# Altium schematic sheet 6.ENERGY.SchDoc
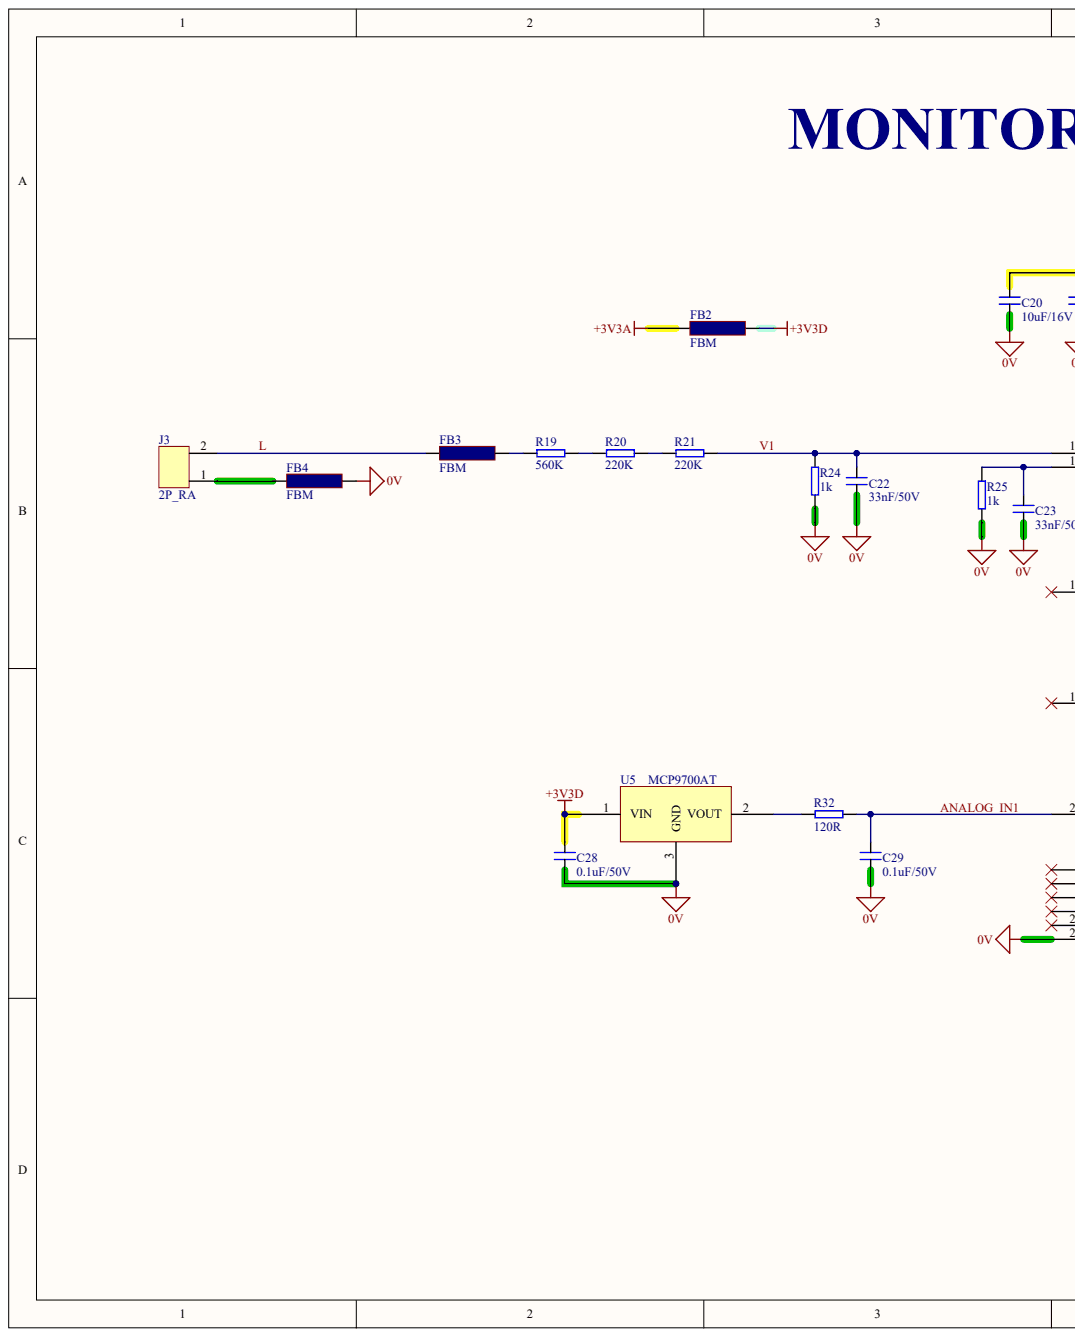
[diagram p0: 6.ENERGY.SchDoc, left]
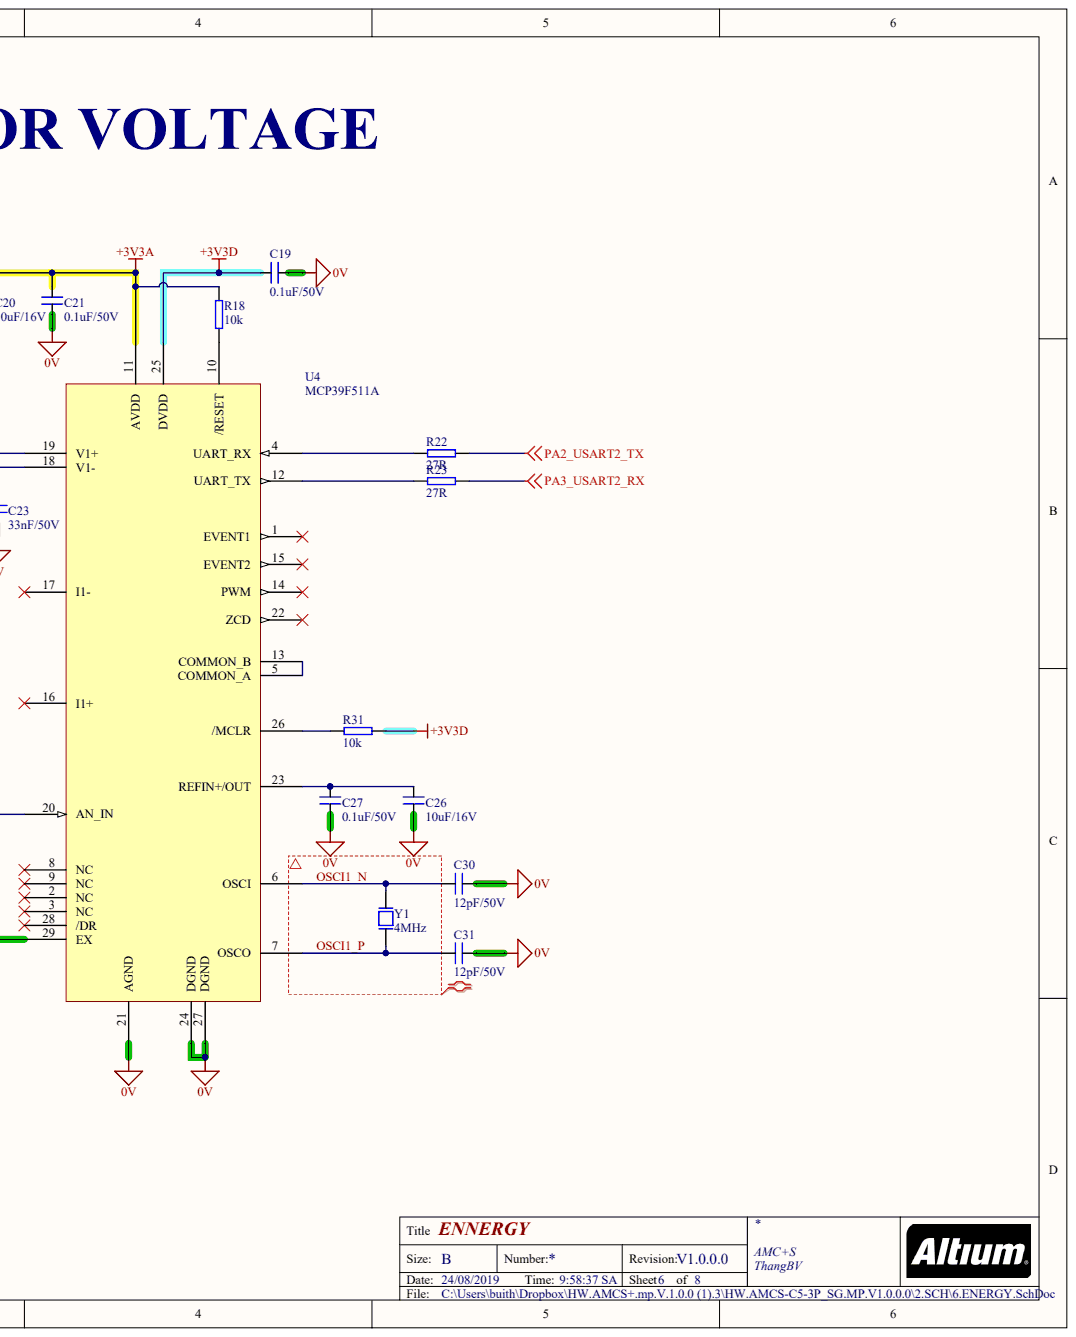
[diagram p1: 6.ENERGY.SchDoc, right]

## Parts
C19  0.1uF/50V  [0805]
C20  10uF/16V  [0805]
C21  0.1uF/50V  [0805]
C22  33nF/50V  [0805]
C23  33nF/50V  [0805]
C26  10uF/16V  [0805]
C27  0.1uF/50V  [0805]
C28  0.1uF/50V  [0805]
C29  0.1uF/50V  [0805]
C30  12pF/50V  [0805]
C31  12pF/50V  [0805]
FB2  FBM  [0805]
FB3  FBM  [0805]
FB4  FBM  [0805]
J3  2P_RA  [CONN_2x1_5.08_RA]
R18  10k  [0805]
R19  560K  [0805]
R20  220K  [0805]
R21  220K  [0805]
R22  27R  [0805]
R23  27R  [0805]
R24  1k  [0805]
R25  1k  [0805]
R31  10k  [0805]
R32  120R  [0805]
U4  MCP39F511A  [QFN-28-EX]
U5  MCP9700AT  [SOT-23]
Y1  4MHz  [49-SMD]

## Nets
0V: C19.2 C20.2 C21.2 C22.1 C23.1 C26.1 C27.2 C28.2 C29.2 C30.1 C31.1 FB4.2 R24.2 R25.2 U4.21(AGND) U4.24(DGND) U4.27(DGND) U4.29(EX) U5.3
+3V3A: C20.1 C21.1 FB2.1 R18.1 U4.11(AVDD)
+3V3D: C19.1 C28.1 FB2.2 R31.1 U4.25(DVDD) U5.1
PA2_USART2_TX: R22.2
PA3_USART2_RX: R23.2
ANALOG_IN1: C29.1 R32.1 U4.20(AN_IN)
L: FB3.1 J3.2
OSCI1_N: C30.2 U4.6(OSCI) Y1.2
OSCI1_P: C31.2 U4.7(OSCO) Y1.1
V1: C22.2 R21.2 R24.1 U4.19(V1+)
NetC23_2: C23.2 R25.1 U4.18(V1-)
NetC26_2: C26.2 C27.1 U4.23(REFIN+/OUT)
NetFB3_2: FB3.2 R19.1
NetFB4_1: FB4.1 J3.1
NetR18_2: R18.2 U4.10(/RESET)
NetR19_2: R19.2 R20.1
NetR20_2: R20.2 R21.1
NetR22_1: R22.1 U4.4(UART_RX)
NetR23_1: R23.1 U4.12(UART_TX)
NetR31_2: R31.2 U4.26(/MCLR)
NetR32_2: R32.2 U5.2
NetU4_5: U4.5(COMMON_A) U4.13(COMMON_B)
N00130:

Not connected: U4 1(EVENT1) 2(NC) 3(NC) 8(NC) 9(NC) 14(PWM) 15(EVENT2) 16(I1+) 17(I1-) 22(ZCD) 28(/DR)

## Library data of the parts
C19 C21 C27-C29 = Cap_0.1uF_0805: CAP CER 0.1UF 50V Y5V 0805; MFR: Yageo; Part Number: CC0805ZRY5V9BB104; AMCS CODE: VT-CAP-0004; ASS: SMT; Component Kind: Standard; Package: 0805; TYPE: CAP; Library Name: Schlib_Diepvn_V01.SchLib
C20 C26 = Cap_10uF_0805: CAP CER 10UF 16V 20% X5R 0805; MFR: Murata; Part Number: GRM21BR61E106KA73L; AMCS CODE: VT-CAP-0005; ASS: SMT; Component Kind: Standard; Package: 0805; TYPE: CAP; Library Name: Schlib_Diepvn_V01.SchLib
C22 C23 = Cap_33nF_0805: CAP CER 0.033UF 50V Y5V 0805; MFR: Panasonic Electronic Components; Part Number: ECJ-2VF1H333Z; Vender Part: PCC1861DKR-ND; ASS: SMT; LINK 1: *https://kme.com.vn/products/tu-gom-0805-33nf-cog-50v; LINK 2: *https://thegioiic.com/products/tu-33nf-50v-0805; Vender: Digikey; Component Kind: Standard; Package: 0805 (2012 Metric); TYPE: CAP; Library Name: Schlib_Diepvn_V01.SchLib
C30 C31 = Cap_12pF_0805: CAP CER 12PF 50V C0G/NPO 0805; MFR: Yageo; Part Number: CC0805JRNPO9BN120; AMCS CODE: VT-CAP-0001; ASS: SMT; Component Kind: Standard; Package: 0805; TYPE: CAP; Library Name: Schlib_Diepvn_V01.SchLib
FB2-FB4 = FERRITBEAD_400mA_0805: FERRITE BEAD 60 OHM 0805 1LN; MFR: Taiyo Yuden; Part Number: BKP2125HS600-T; AMCS CODE: VT-IND-0001; ASS: SMT; TYPE: IND; Component Kind: Standard; Package: 0805; Library Name: Schlib_Diepvn_V01.SchLib
J3 = CON_2_5.08: HEADER 2 AC 5.08MM EDGE 90 DEG; Part Number: CON2 2EDG5.08-2P; AMCS CODE: VT-CON-0013; ASS: TH; Component Kind: Standard; Package: Pitch: 5.08MM; TYPE: CONN; Library Name: Schlib_Diepvn_V01.SchLib
R18 R31 = Res_10k_0805: RES SMD 10K OHM 1% 1/8W 0805; MFR: Yageo; Part Number: RC0805FR-0710KL; AMCS CODE: VT-RES-0001; ASS: SMT; Component Kind: Standard; Package: 0805; TYPE: RES; Library Name: Schlib_Diepvn_V01.SchLib
R19 = Res_560K_0805: RES SMD 560K OHM 1% 1/8W 0805; MFR: Yageo; Part Number: RC0805FR-07560KL; AMCS CODE: VT-RES-0012; ASS: SMT; Component Kind: Standard; Package: 0805; TYPE: RES; Library Name: Schlib_Diepvn_V01.SchLib
R20 R21 = Res_220K_0805: RES SMD 220K OHM 1% 1/8W 0805; MFR: Yageo; Part Number: RC0805FR-07220KL; Vender Part: 311-220KCRTR-ND; ASS: SMT; Vender: Digikey; Component Kind: Standard; Package: 0805; TYPE: RES; Library Name: Schlib_Diepvn_V01.SchLib
R22 R23 = Res_27R_0805: RES SMD 27 OHM 1% 1/8W 0805; MFR: Yageo; Part Number: RC0805FR-0727RL; AMCS CODE: VT-RES-0007; ASS: SMT; Component Kind: Standard; Package: 0805; TYPE: RES; Library Name: Schlib_Diepvn_V01.SchLib
R24 R25 = Res_1k_0805: RES 1K OHM 1/10W 1% 0603 SMD; MFR: Yageo; Part Number: RC0805FR-071KL; AMCS CODE: VT-RES-0003; ASS: SMT; Component Kind: Standard; Package: 0805; TYPE: RES; Library Name: Schlib_Diepvn_V01.SchLib
R32 = Res_120R_0805: RES SMD 120 OHM 1% 1/8W 0805; MFR: Yageo; Part Number: RC0805FR-07120RL; AMCS CODE: VT-RES-0008; ASS: SMT; Component Kind: Standard; Package: 0805; TYPE: RES; Library Name: Schlib_Diepvn_V01.SchLib
U4 = MCP39F511_A: IC POWER MONITOR 1PH 28QFN; MFR: MCP; Part Number: MCP39F511-E/MQ; ASS: SMT; Current - Supply: 7mA; Voltage - Supply: 2.7V ~ 3.6V; Component Kind: Standard; Operating Temperature: -40°C ~ 125°C; Package: 28-VFQFN Exposed Pad; TYPE: IC; Library Name: Schlib_Diepvn_V01.SchLib
U5 = MCP9700A: SENSOR ANALOG -40C-125C SOT23-3; MFR: Microchip Technology; Part Number: MCP9700AT-E/TT; Vender Part Number: *MCP9700AT-E/TTTR-ND; ASS: SMT; Vender: Digi-Key; Component Kind: Standard; Package: SOT23-3; TYPE: IC; Library Name: Schlib_Diepvn_V01.SchLib
Y1 = CRY_4MHZ_49SMD: CRYSTAL 4.0000MHZ 12PF SMD; MFR: Kyocera International Inc. Electronic Components; Part Number: CX8045GA04000H0PST03; ASS: SMT; Component Kind: Standard; Package: HC49/US; TYPE: CRY; Library Name: Schlib_Diepvn_V01.SchLib
